# Altium schematic sheet 7_VGA.SchDoc
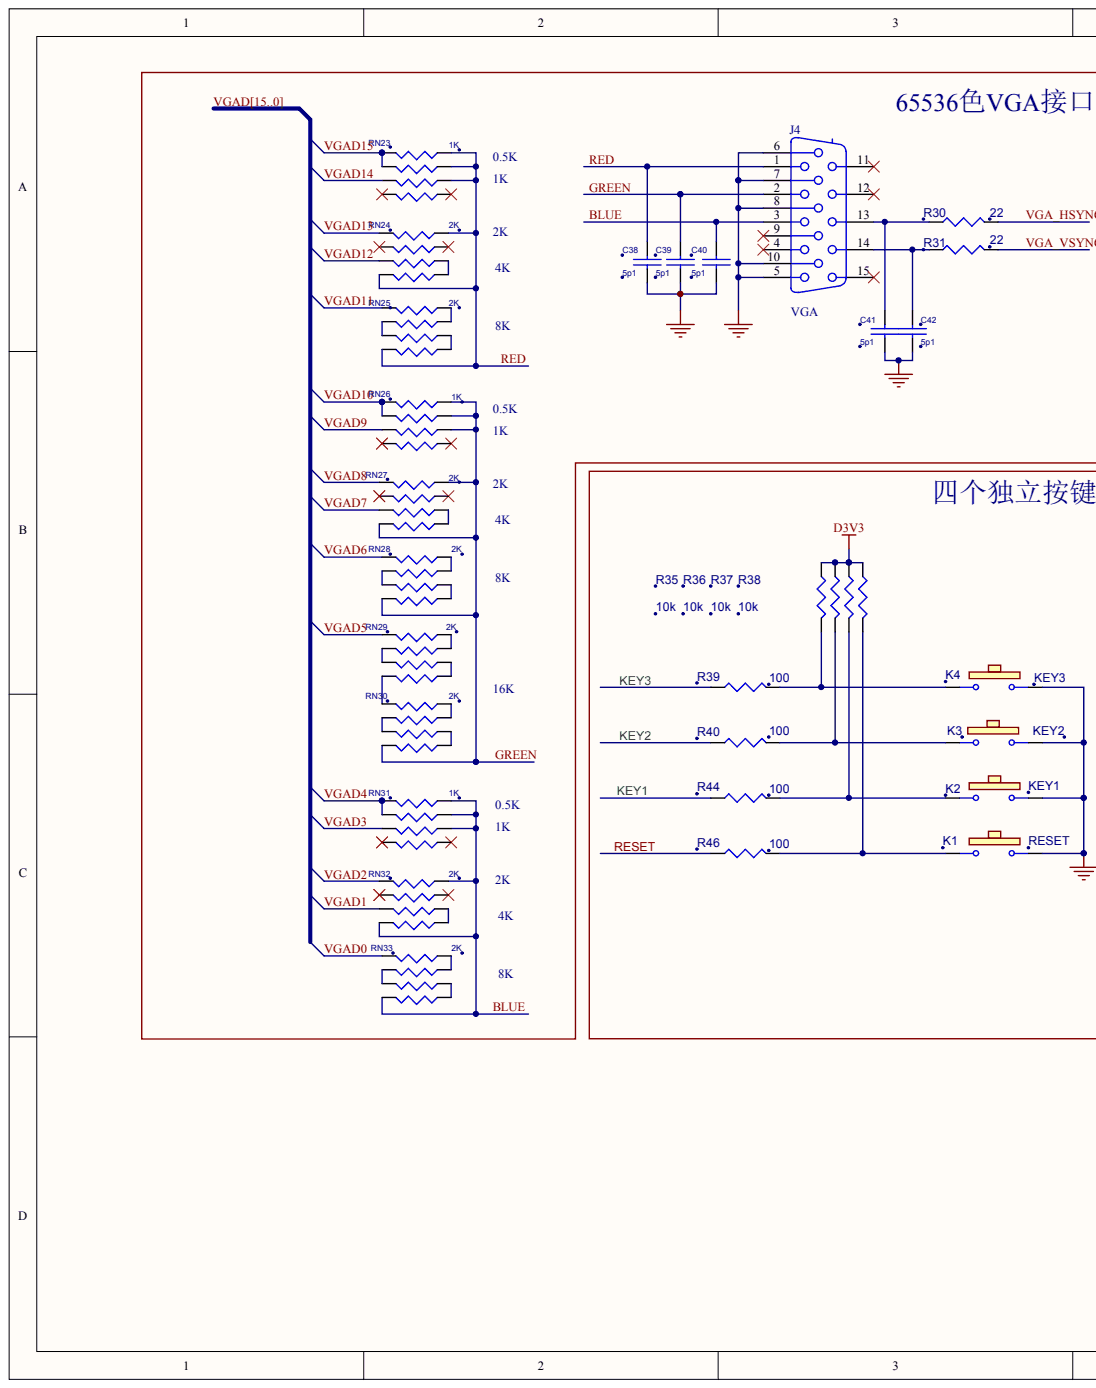
[diagram p0: 7_VGA.SchDoc, left]
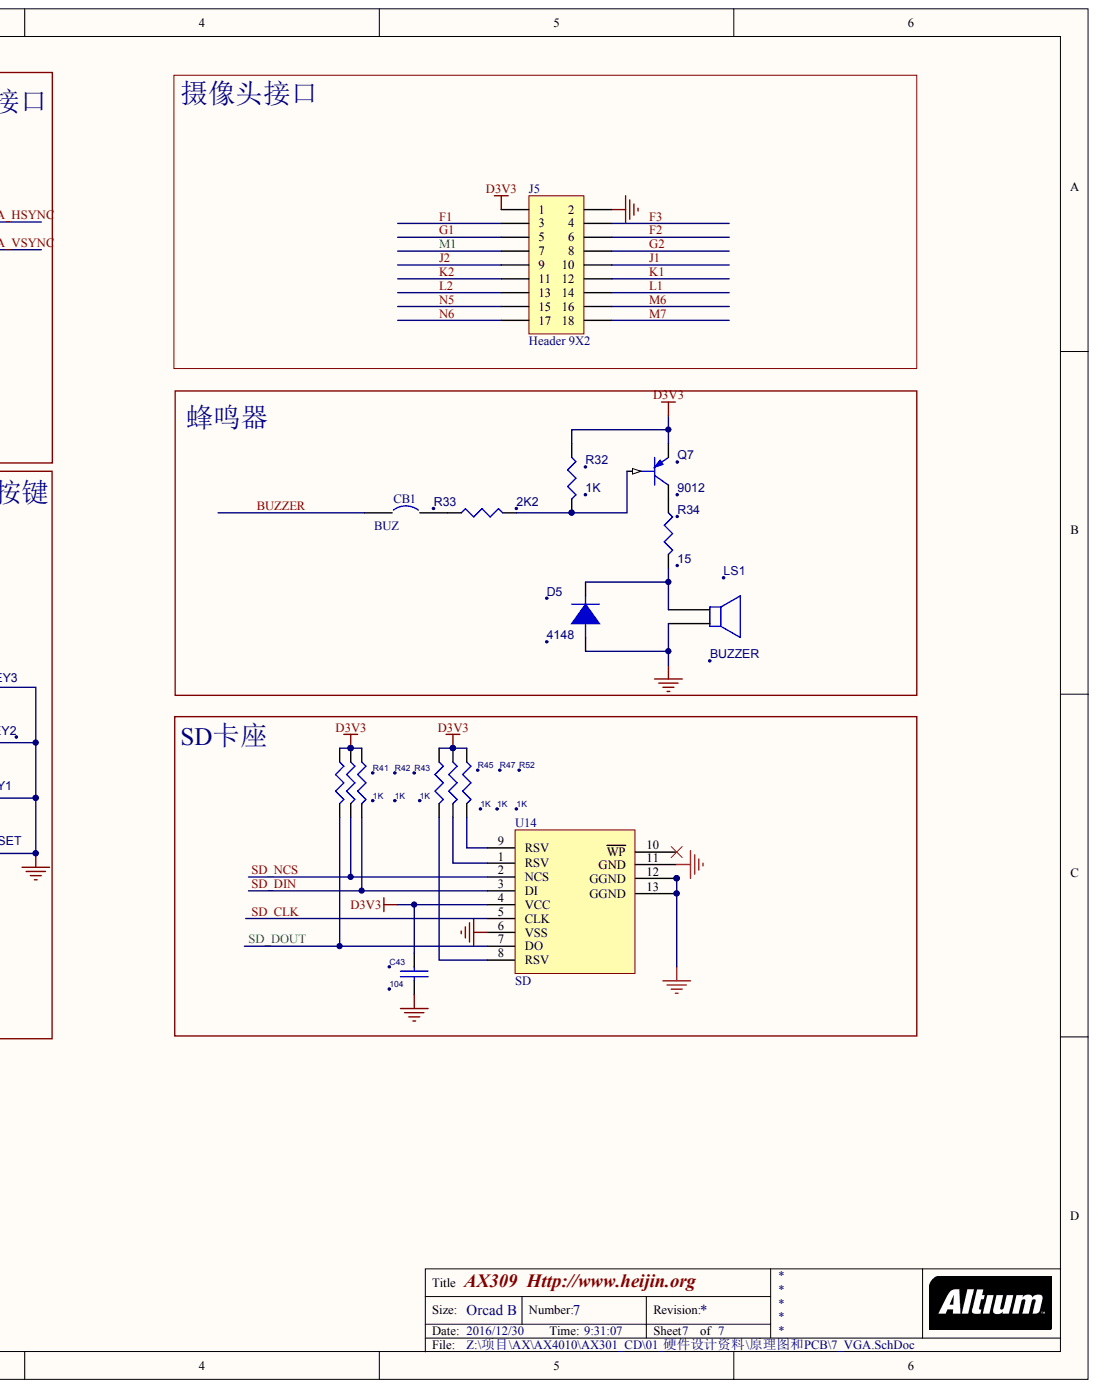
[diagram p1: 7_VGA.SchDoc, right]

## Parts
C38  5p1
C39  5p1
C40  5p1
C41  5p1
C42  5p1
C43  104
CB1  BUZ
D5  4148
J4  VGA
J5  Header 9X2
K1  RESET
K2  KEY1
K3  KEY2
K4  KEY3
LS1  BUZZER
Q7  9012
R30  22
R31  22
R32  1K
R33  2K2
R34  15
R35  10k
R36  10k
R37  10k
R38  10k
R39  100
R40  100
R41  1K
R42  1K
R43  1K
R44  100
R45  1K
R46  100
R47  1K
R52  1K
RN23  1K
RN24  2K
RN25  2K
RN26  1K
RN27  2K
RN28  2K
RN29  2K
RN30  2K
RN31  1K
RN32  2K
RN33  2K
U14  SD

## Nets
D3V3: C43.1 J5.1 Q7.2 R32.1 R35.1 R36.1 R37.1 R38.1 R41.1 R42.1 R43.1 R45.1 R47.1 R52.1 U14.4(VCC)
GND: C38.2 C39.2 C40.2 C41.2 C42.2 C43.2 D5.1 J4.5 J4.6 J4.7 J4.8 J4.10 J5.2 K1.2 K2.2 K3.1 K4.2 LS1.2 U14.6(VSS) U14.11(GND) U14.12(GGND) U14.13(GGND)
BLUE: C40.1 J4.3 RN31.2 RN31.3 RN31.4 RN32.4 RN32.8 RN33.8
BUZZER: CB1.1
F1: J5.3
F2: J5.6
F3: J5.4
G1: J5.5
G2: J5.8
GREEN: C39.1 J4.2 RN26.2 RN26.3 RN26.4 RN27.4 RN27.8 RN28.8 RN30.8
J1: J5.10
J2: J5.9
K1: J5.12
K2: J5.11
KEY1: R44.1
KEY2: R40.1
KEY3: R39.1
L1: J5.14
L2: J5.13
M1: J5.7
M6: J5.16
M7: J5.18
N5: J5.15
N6: J5.17
RED: C38.1 J4.1 RN23.2 RN23.3 RN23.4 RN24.4 RN24.8 RN25.8
RESET: R46.1
SD_CLK: U14.5(CLK)
SD_DIN: R43.2 U14.3(DI)
SD_DOUT: R41.2 U14.7(DO)
SD_NCS: R42.2 U14.2(NCS)
VGA_HSYNC: R30.2
VGA_VSYNC: R31.2
VGAD0: RN33.5  [also labelled VGAD]
VGAD1: RN32.7  [also labelled VGAD]
VGAD2: RN32.5  [also labelled VGAD]
VGAD3: RN31.7  [also labelled VGAD]
VGAD4: RN31.5 RN31.6  [also labelled VGAD]
VGAD5: RN29.5  [also labelled VGAD]
VGAD6: RN28.5  [also labelled VGAD]
VGAD7: RN27.7  [also labelled VGAD]
VGAD8: RN27.5  [also labelled VGAD]
VGAD9: RN26.7  [also labelled VGAD]
VGAD10: RN26.5 RN26.6  [also labelled VGAD]
VGAD11: RN25.5  [also labelled VGAD]
VGAD12: RN24.7  [also labelled VGAD]
VGAD13: RN24.5  [also labelled VGAD]
VGAD14: RN23.7  [also labelled VGAD]
VGAD15: RN23.5 RN23.6  [also labelled VGAD]
NetC41_1: C41.1 J4.13 R30.1
NetC42_1: C42.1 J4.14 R31.1
NetCB1_2: CB1.2 R33.1
NetD5_2: D5.2 LS1.1 R34.2
NetK1_1: K1.1 R38.2 R46.2
NetK2_1: K2.1 R37.2 R44.2
NetK3_2: K3.2 R36.2 R40.2
NetK4_1: K4.1 R35.2 R39.2
NetQ7_1: Q7.1 R32.2 R33.2
NetQ7_3: Q7.3 R34.1
NetR45_2: R45.2 U14.8(RSV)
NetR47_2: R47.2 U14.1(RSV)
NetR52_2: R52.2 U14.9(RSV)
NetRN24_1: RN24.1 RN24.2
NetRN25_1: RN25.1 RN25.2
NetRN25_3: RN25.3 RN25.4
NetRN25_6: RN25.6 RN25.7
NetRN27_1: RN27.1 RN27.2
NetRN28_1: RN28.1 RN28.2
NetRN28_3: RN28.3 RN28.4
NetRN28_6: RN28.6 RN28.7
NetRN29_1: RN29.1 RN29.2
NetRN29_3: RN29.3 RN29.4
NetRN29_6: RN29.6 RN29.7
NetRN29_8: RN29.8 RN30.5
NetRN30_1: RN30.1 RN30.2
NetRN30_3: RN30.3 RN30.4
NetRN30_6: RN30.6 RN30.7
NetRN32_1: RN32.1 RN32.2
NetRN33_1: RN33.1 RN33.2
NetRN33_3: RN33.3 RN33.4
NetRN33_6: RN33.6 RN33.7

Not connected: J4 4 9 11 12 15; RN23 1 8; RN24 3 6; RN26 1 8; RN27 3 6; RN31 1 8; RN32 3 6; U14 10(WP)

## Library data of the parts
J4 = (no symbol name): PackageDocument: B; Positions: 15; Gender: Receptacle Header; Published: 15-Jun-2001; LatestRevisionDate: 17-Jul-2002; LatestRevisionNote: Re-released for DXP Platform.; DatasheetDocument: 24-Nov-1999; PackageReference: 788574; Orientation: Right Angle; Publisher: Altium Limited
C38-C43 CB1 D5 J5 K1-K4 LS1 Q7 R30-R47 R52 RN23-RN33 U14 = (no symbol name)
  CB1: Published: 24-Mar-1999; LatestRevisionDate: 17-Jul-2002; LatestRevisionNote: Re-released for DXP Platform.; PackageReference: SPST-2; PackageDescription: Switch; 2 Leads
  J5: LatestRevisionDate: 17-Jul-2002; LatestRevisionNote: Re-released for DXP Platform.
  CB1 J5: Publisher: Altium Limited
